# Altium schematic sheet doorlock.SchDoc
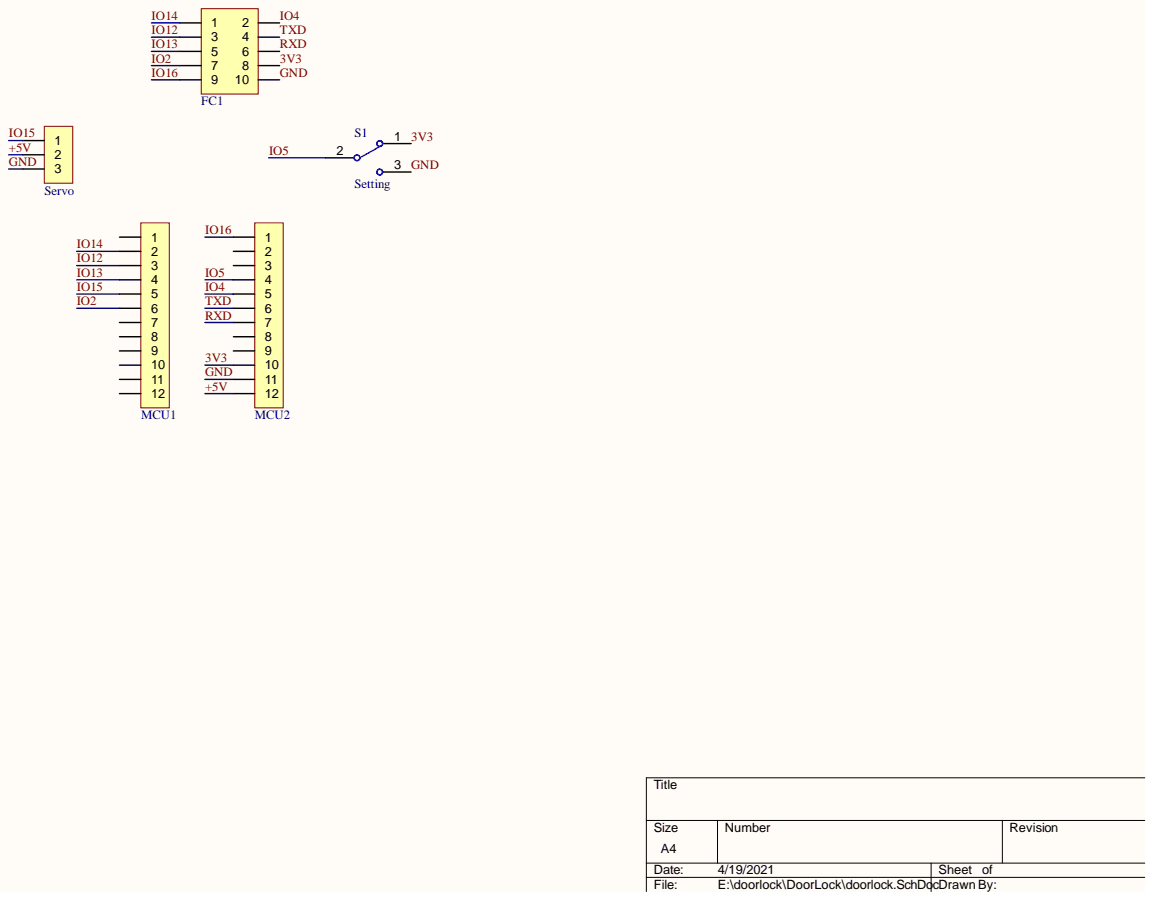
[diagram p0: doorlock.SchDoc]

## Parts
FC1  Header 5X2  [IDC2.54-LI-2x5P]  (value not drawn)
MCU1  MCU 1  [HDR2.54-M-LI-12P]
MCU2  MCU 2  [HDR2.54-M-LI-12P]
S1  Setting  [SS-12D10]
Servo  HDR-1X3  [HDR2.54-WI-3P]  (value not drawn)

## Nets
3V3: FC1.8 MCU2.10 S1.1
+5V: MCU2.12 Servo.2
GND: FC1.10 MCU2.11 S1.3 Servo.3
IO2: FC1.7 MCU1.6
IO4: FC1.2 MCU2.5
IO5: MCU2.4 S1.2
IO12: FC1.3 MCU1.3
IO13: FC1.5 MCU1.4
IO14: FC1.1 MCU1.2
IO15: MCU1.5 Servo.1
IO16: FC1.9 MCU2.1
RXD: FC1.6 MCU2.7
TXD: FC1.4 MCU2.6

Not connected: MCU1 1 7 8 9 10 11 12; MCU2 2 3 8 9

## Library data of the parts
FC1 = Header 5X2: 5*2P接插件; 封装库来自淘宝卖家 ID：黄晶宝石: 其他店铺购入均为盗版假冒封装库; 联系卖家，免费查找封装: 唯一出售店铺网址https://shop463601444.taobao.com; BOM名称: 5*2P接插件; Component Kind: Standard; Library Name: 5.5 - 接插件-脚距2.54 (100mil) .SchLib
MCU1 MCU2 = Header 12: 12P接插件; 封装库来自淘宝卖家 ID：黄晶宝石: 其他店铺购入均为盗版假冒封装库; 联系卖家，免费查找封装: 唯一出售店铺网址https://shop463601444.taobao.com; BOM名称: 12P接插件; Component Kind: Standard; Library Name: 5.5 - 接插件-脚距2.54 (100mil) .SchLib
S1 = SSW-1P: 1路波动开关; BOM名称: 波动开关; 联系卖家，免费查找封装: 唯一出售店铺网址https://shop463601444.taobao.com; Component Kind: Standard; Library Name: 0.7 - 常用按键-开关-蜂鸣器-电池-测试点.SchLib
Servo = Header 3: 3P接插件; 封装库来自淘宝卖家 ID：黄晶宝石: 其他店铺购入均为盗版假冒封装库; 联系卖家，免费查找封装: 唯一出售店铺网址https://shop463601444.taobao.com; BOM名称: 3P接插件; Component Kind: Standard; Library Name: 5.5 - 接插件-脚距2.54 (100mil) .SchLib
